# Altium schematic sheet MC.SchDoc
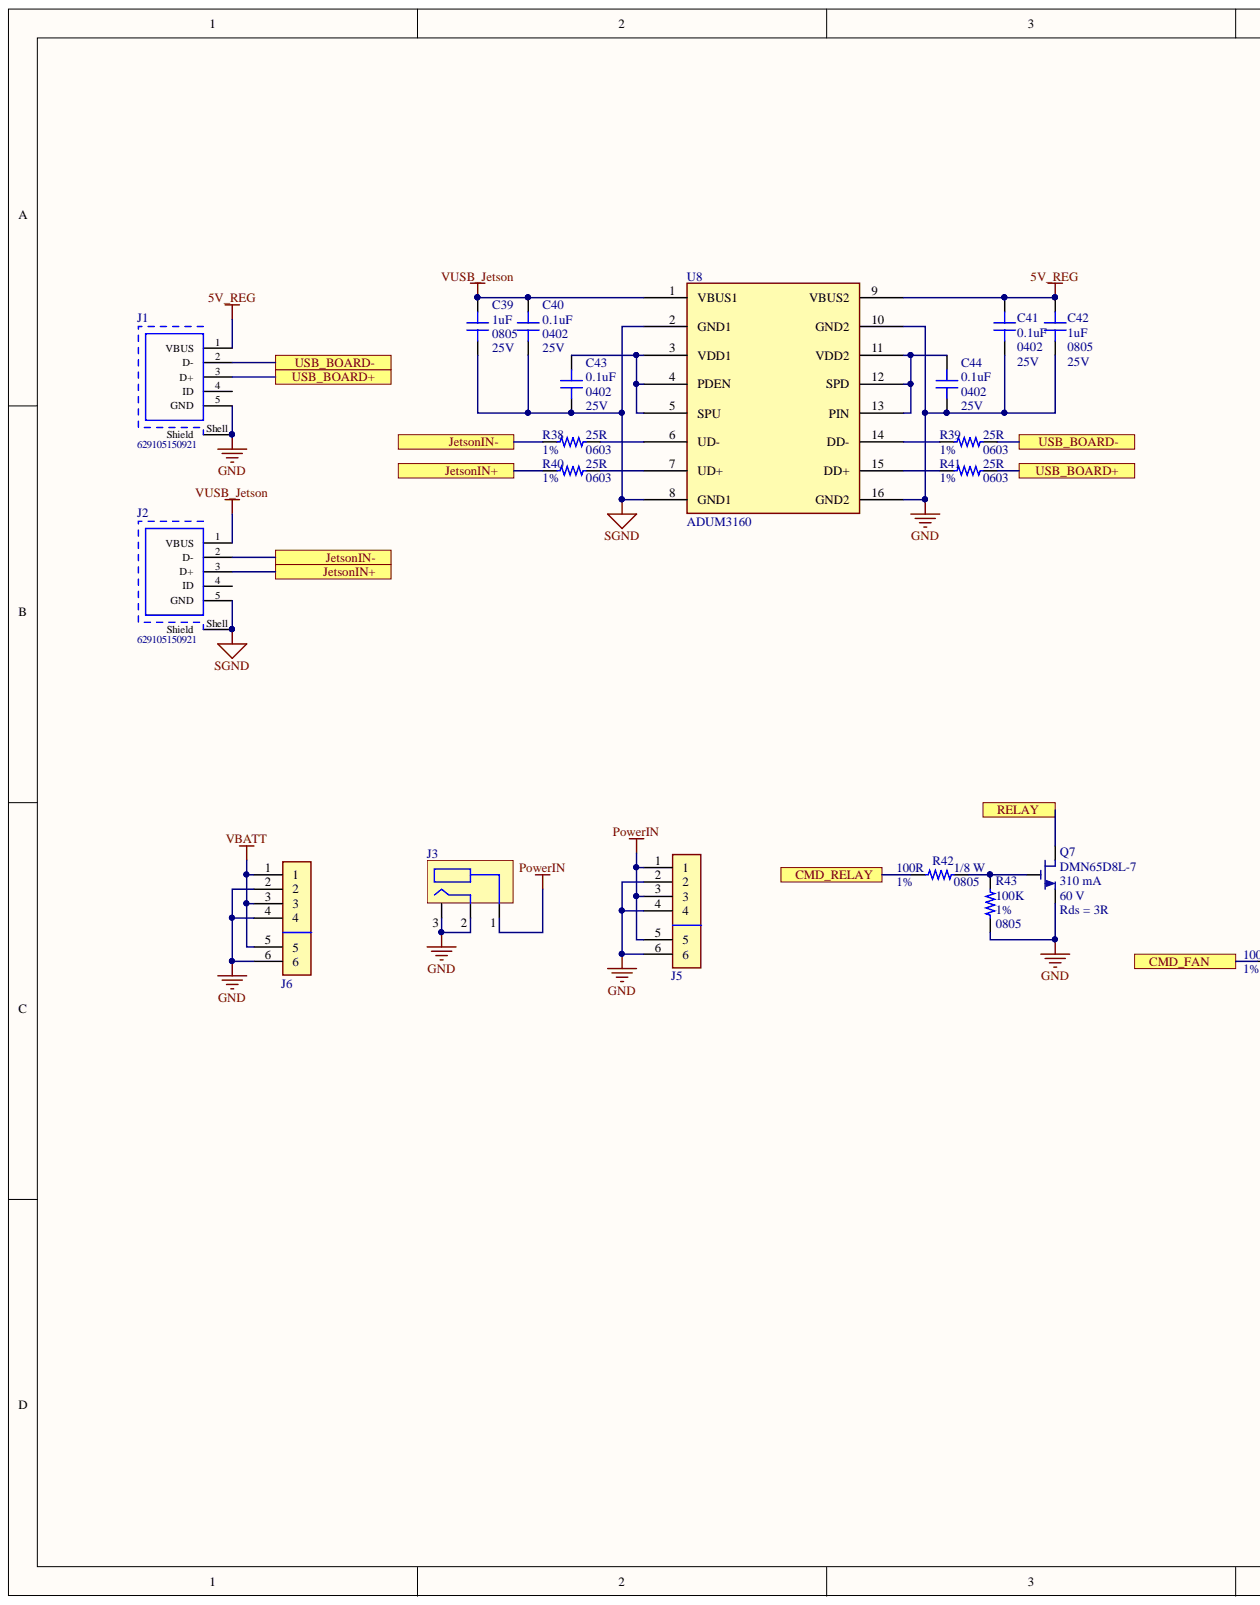
[diagram p0: MC.SchDoc, left]
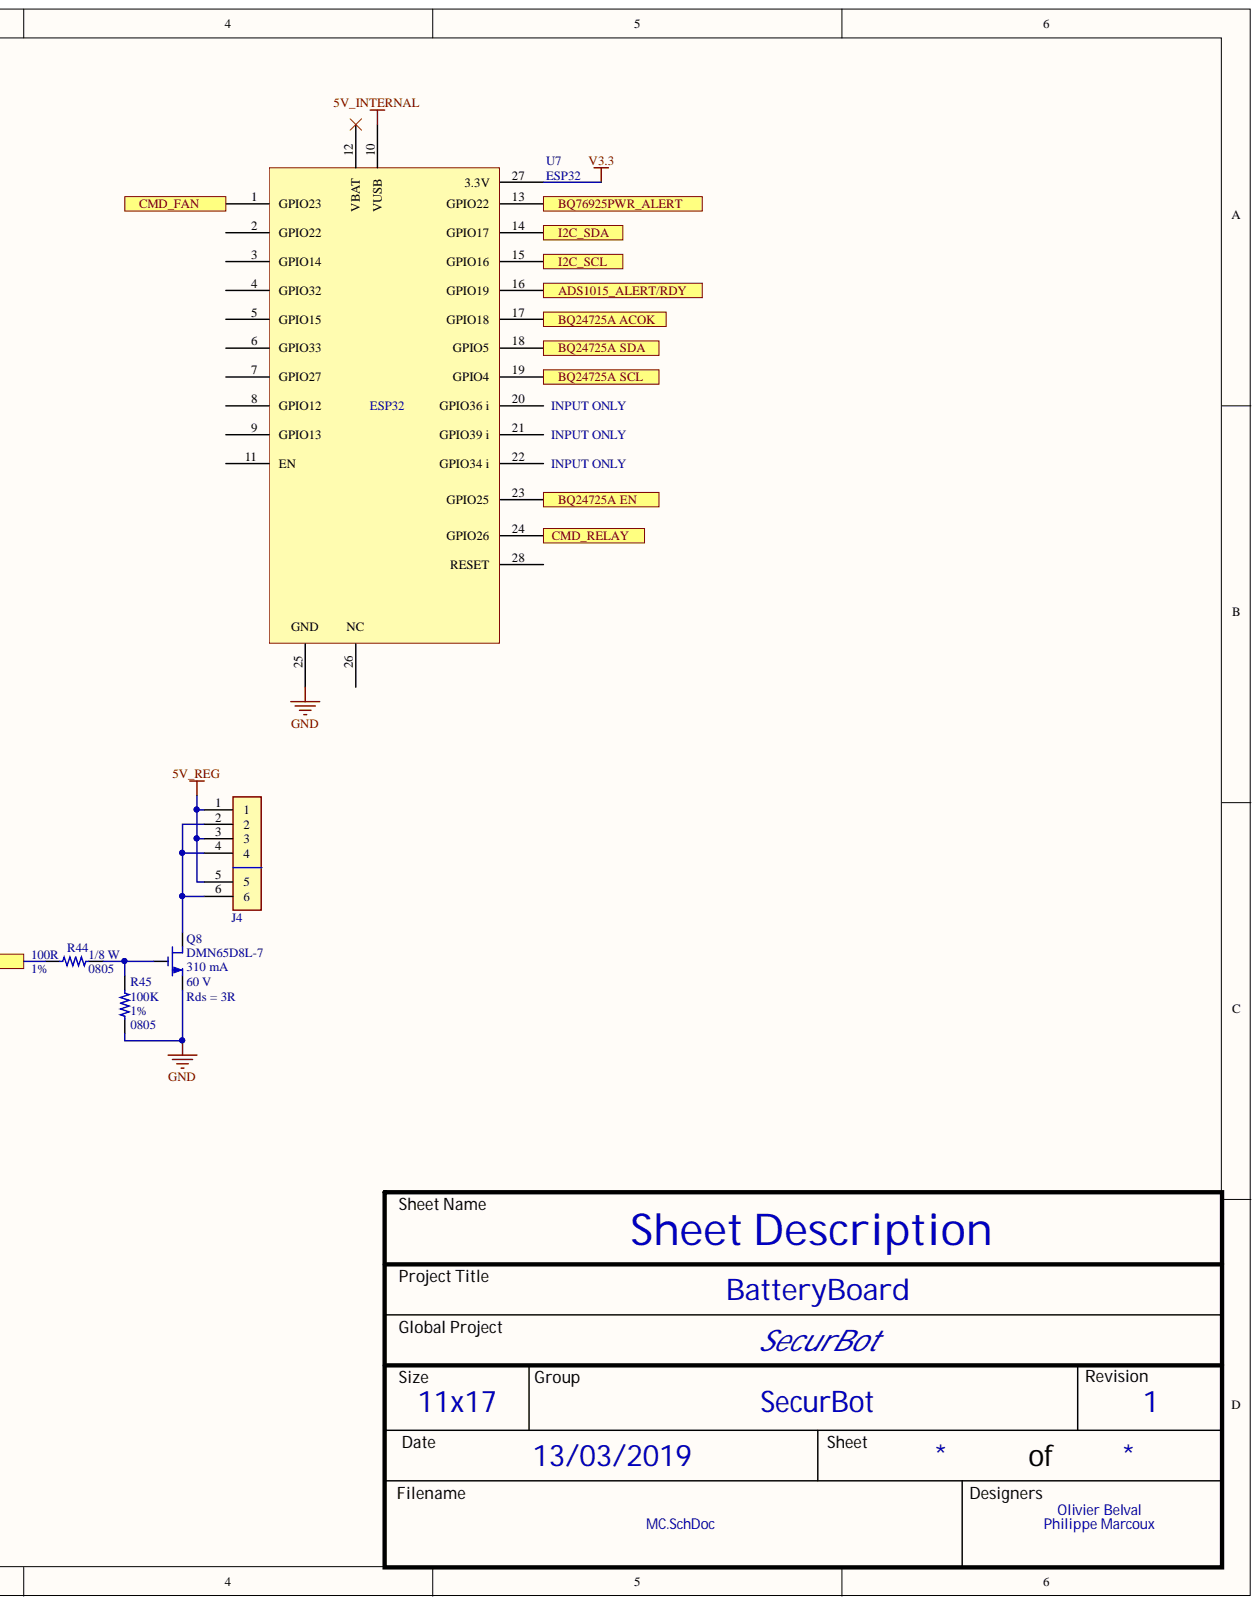
[diagram p1: MC.SchDoc, right]

## Parts
C39  value 1uF  Package: 0805  Voltage: 25V  [CAP_0805]  (hidden comment: CAP - 0805)
C40  value 0.1uF  Package: 0402  Voltage: 25V  [CAP_0402]  (hidden comment: CAP - 0402)
C41  value 0.1uF  Package: 0402  Voltage: 25V  [CAP_0402]  (hidden comment: CAP - 0402)
C42  value 1uF  Package: 0805  Voltage: 25V  [CAP_0805]  (hidden comment: CAP - 0805)
C43  value 0.1uF  Package: 0402  Voltage: 25V  [CAP_0402]  (hidden comment: CAP - 0402)
C44  value 0.1uF  Package: 0402  Voltage: 25V  [CAP_0402]  (hidden comment: CAP - 0402)
J1  629105150921  [629105150921]
J2  629105150921  [629105150921]
J3  Barrel 5.5x2.5mm  [Barrel 5.5mm 2.5mm]  (value not drawn)
J4  MOLEX 4 POS - TERMINAL BLOCK 2 POS  [MOLEX4POS_TERMBLOCK2POS]  (value not drawn)
J5  MOLEX 4 POS - TERMINAL BLOCK 2 POS  [MOLEX4POS_TERMBLOCK2POS]  (value not drawn)
J6  MOLEX 4 POS - TERMINAL BLOCK 2 POS  [MOLEX4POS_TERMBLOCK2POS]  (value not drawn)
Q7  A max: 310 mA  Part number: DMN65D8L-7  Ron: Rds = 3R  Vds max: 60 V  [SOT-23]  (hidden comment: NMOS)
Q8  A max: 310 mA  Part number: DMN65D8L-7  Ron: Rds = 3R  Vds max: 60 V  [SOT-23]  (hidden comment: NMOS)
R38  value 25R  Package: 0603  Tolerance: 1%  [RES_0603]  (hidden comment: RES - 0402)
R39  value 25R  Package: 0603  Tolerance: 1%  [RES_0603]  (hidden comment: RES - 0402)
R40  value 25R  Package: 0603  Tolerance: 1%  [RES_0603]  (hidden comment: RES - 0402)
R41  value 25R  Package: 0603  Tolerance: 1%  [RES_0603]  (hidden comment: RES - 0402)
R42  value 100R  Package: 0805  Tolerance: 1%  Watt: 1/8 W  [RES_0805]  (hidden comment: RES - 0805)
R43  value 100K  Package: 0805  Tolerance: 1%  [RES_0805]  (hidden comment: RES - 0805)
R44  value 100R  Package: 0805  Tolerance: 1%  Watt: 1/8 W  [RES_0805]  (hidden comment: RES - 0805)
R45  value 100K  Package: 0805  Tolerance: 1%  [RES_0805]  (hidden comment: RES - 0805)
U7  ESP32  [ESP32 V1]
U8  ADUM3160  [SOIC16]

## Ports
ADS1015_ALERT/RDY, BQ24725A ACOK, BQ24725A EN, BQ24725A SCL, BQ24725A SDA, BQ76925PWR_ALERT, CMD_FAN, CMD_RELAY, I2C_SCL, I2C_SDA, JetsonIN+, JetsonIN-, RELAY, USB_BOARD+, USB_BOARD-

## Nets
5V_INTERNAL: U7.10(VUSB)
5V_REG: C41.2 C42.1 J1.1(VBUS) J4.1 J4.3 J4.5 U8.9(VBUS2)
GND: C41.1 C42.2 C44.1 J1.5(GND) J1.Shell(Shield) J3.2 J3.3 J5.2 J5.4 J5.6 J6.2 J6.4 J6.6 Q7.1 Q8.1 R43.1 R45.1 U7.25 U8.10(GND2) U8.16(GND2)
PowerIN: J3.1 J5.1 J5.3 J5.5
SGND: C39.2 C40.1 C43.1 J2.5(GND) J2.Shell(Shield) U8.2(GND1) U8.8(GND1)
V3.3: U7.27(3.3V)
VBATT: J6.1 J6.3 J6.5
VUSB_Jetson: C39.1 C40.2 J2.1(VBUS) U8.1(VBUS1)
ADS1015_ALERT/RDY: U7.16(GPIO19) ; port ADS1015_ALERT/RDY
BQ24725A ACOK: U7.17(GPIO18) ; port BQ24725A ACOK
BQ24725A EN: U7.23(GPIO25) ; port BQ24725A EN
BQ24725A SCL: U7.19(GPIO4) ; port BQ24725A SCL
BQ24725A SDA: U7.18(GPIO5) ; port BQ24725A SDA
BQ76925PWR_ALERT: U7.13(GPIO22) ; port BQ76925PWR_ALERT
CMD_FAN: R44.1 U7.1(GPIO23) ; port CMD_FAN
CMD_RELAY: R42.1 U7.24(GPIO26) ; port CMD_RELAY
I2C_SCL: U7.15(GPIO16) ; port I2C_SCL
I2C_SDA: U7.14(GPIO17) ; port I2C_SDA
RELAY: Q7.3 ; port RELAY
NetC43_2: C43.2 U8.3(VDD1) U8.4(PDEN) U8.5(SPU)
NetC44_2: C44.2 U8.11(VDD2) U8.12(SPD) U8.13(PIN)
NetJ1_2: J1.2(D-) R39.2
NetJ1_3: J1.3(D+) R41.2
NetJ2_2: J2.2(D-) R38.1
NetJ2_3: J2.3(D+) R40.1
NetJ4_2: J4.2 J4.4 J4.6 Q8.3
NetQ7_2: Q7.2 R42.2 R43.2
NetQ8_2: Q8.2 R44.2 R45.2
NetR38_2: R38.2 U8.6(UD-)
NetR39_1: R39.1 U8.14(DD-)
NetR40_2: R40.2 U8.7(UD+)
NetR41_1: R41.1 U8.15(DD+)

Not connected: J1 4(ID); J2 4(ID); U7 2(GPIO22) 3(GPIO14) 4(GPIO32) 5(GPIO15) 6(GPIO33) 7(GPIO27) 8(GPIO12) 9(GPIO13) 11(EN) 12(VBAT) 20(GPIO36_i) 21(GPIO39_i) 22(GPIO34_i) 26(NC) 28(RESET)

## Library data of the parts
C39 C42 = CAP - 0805: Part number: CL21B105KAFNNNE; Component Kind: Standard; Description: CAP - 0805; Library Name: SecureBot.SchLib
C40 C41 C43 C44 = CAP - 0402: Part number: CL05A104KA5NNNC; Component Kind: Standard; Description: CAP - 0402; Library Name: SecureBot.SchLib
J1 J2 = 629105150921: Micro USB 2.0 Type AB Receptacle WR-COM, Horizontal, SMT; Contact Resistance: 30 mOhm; Rated Current: 1.8 A; Working Voltage: 30 VAC; Component Kind: Standard; ComponentLink1Description: Manufacturer; ComponentLink1URL: http://www.we-online.de; ComponentLink2Description: Datasheet; ComponentLink2URL: https://katalog.we-online.de/em/datasheet/629105150921.pdf; Connector Type: Micro USB 2.0 Type AB; Gender: Receptacle; Library Name: Altium_WR-COM_USB-Connectors (rev18d).IntLib; Mounting Technology: SMT; Operating Temperature: -40 to 85 degC; Orientation: Horizontal; Packaging: Tape and Reel; Part Number: 629105150921; Published Date: September 2018; Publisher: Wurth Elektronik
J3 = Barrel 5.5x2.5mm: Jack 5.5x2.5mm; Part number: PJ-082BH; Component Kind: Standard; Library Name: SecureBot.SchLib
J4-J6 = MOLEX 4 POS - TERMINAL BLOCK 2 POS: Part number: OSTOQ023250; Part number: 0039281043; Component Kind: Standard; Library Name: SecureBot.SchLib
R38-R41 = RES - 0402: Part number: RMCF0603FT27R0CT-ND; Watt: 0.1W; Component Kind: Standard; Description: RES - 0402; Library Name: SecureBot.SchLib
R42 R44 = RES - 0805: Part number: ERA-6AEB101V; Component Kind: Standard; Description: RES - 0805; Library Name: SecureBot.SchLib
R43 R45 = RES - 0805: Part number: RMCF0805FT100K; Watt: 1/8 W; Component Kind: Standard; Description: RES - 0805; Library Name: SecureBot.SchLib
Q7 Q8 = NMOS: Component Kind: Standard; Library Name: SecureBot.SchLib
U7 = ESP32: Component Kind: Standard; Library Name: SecureBot.SchLib
U8 = ADUM3160: Component Kind: Standard; Part number: ADUM3160; Library Name: SecureBot.SchLib
